# Altium schematic sheet Rikky-board_v0r0_SF2.SchDoc
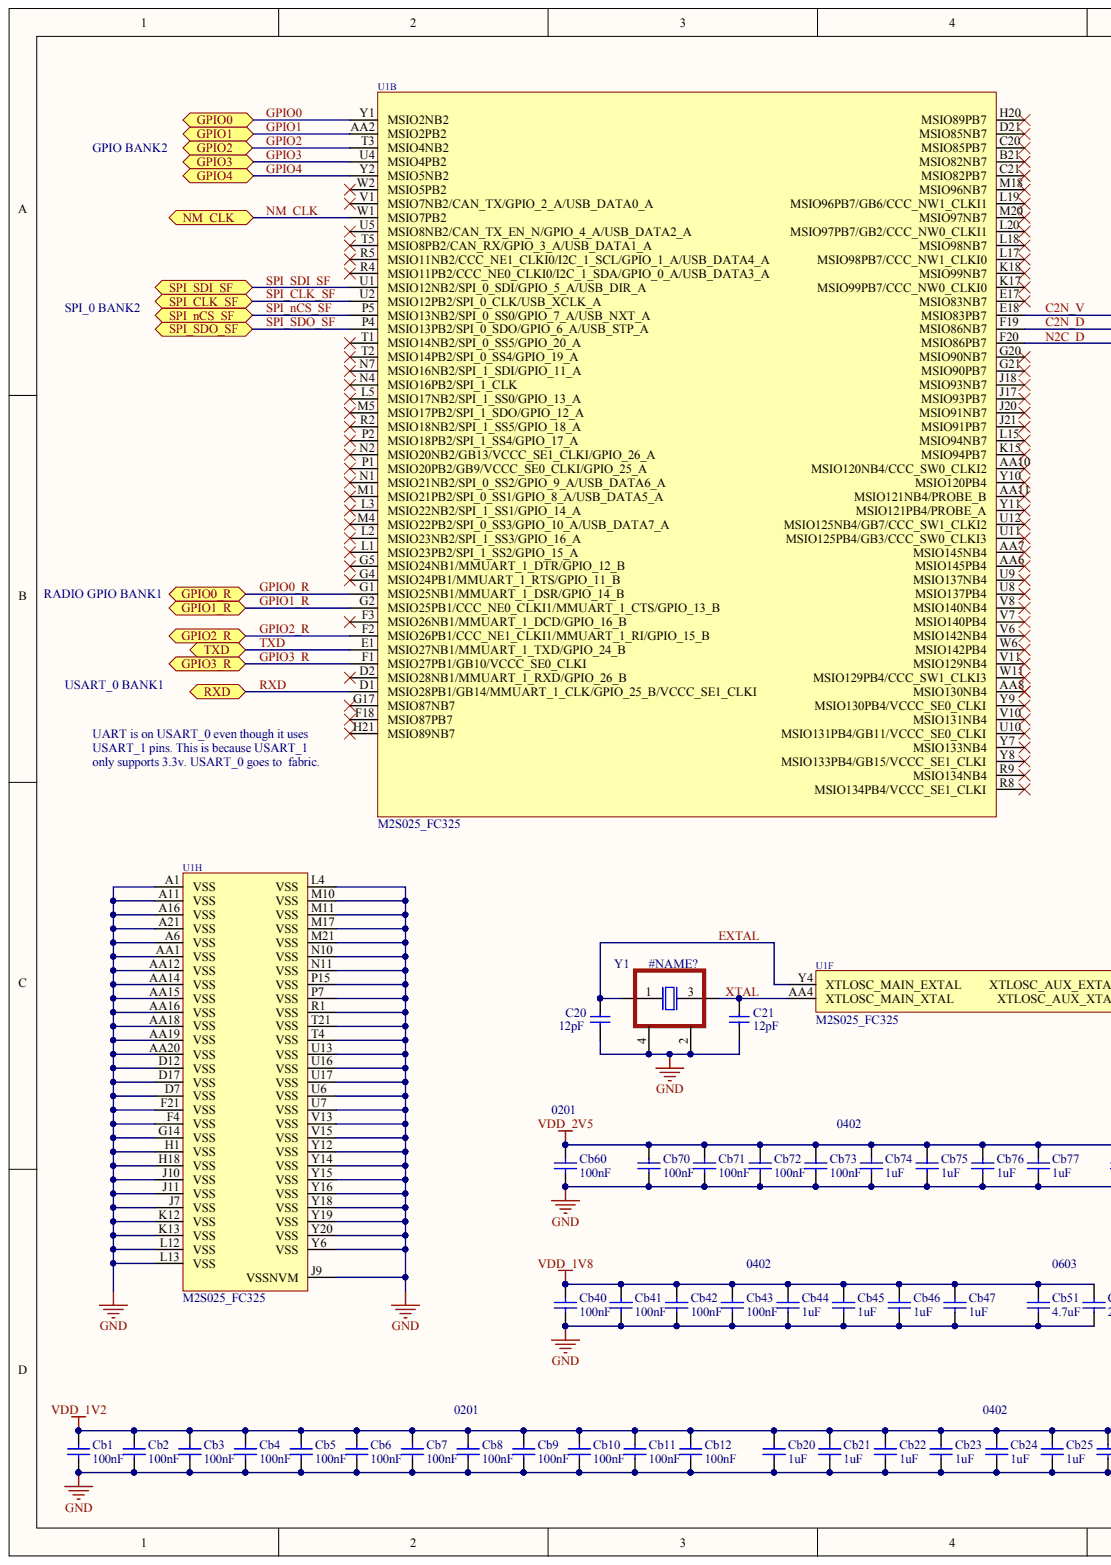
[diagram p0: Rikky-board_v0r0_SF2.SchDoc, left]
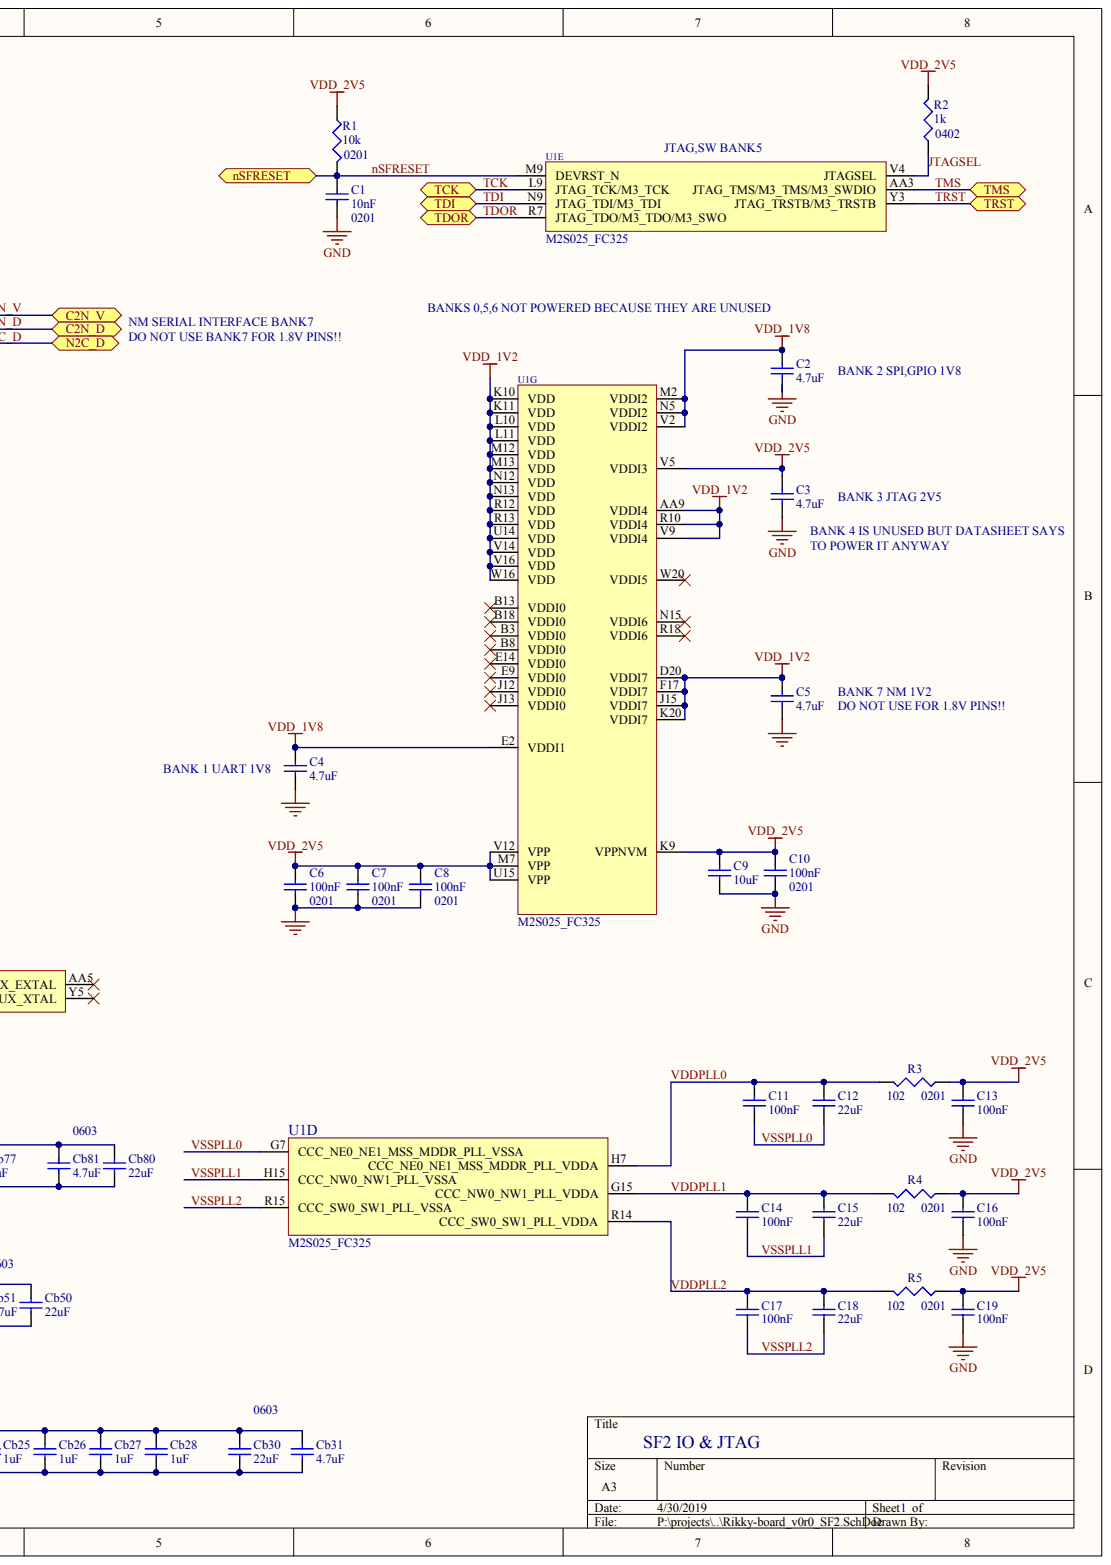
[diagram p1: Rikky-board_v0r0_SF2.SchDoc, right]

## Parts
C1  0201  value 10nF
C2  value 4.7uF  (hidden comment: GRM188C81E475KE11D)
C3  value 4.7uF  (hidden comment: GRM188C81E475KE11D)
C4  value 4.7uF  (hidden comment: GRM188C81E475KE11D)
C5  value 4.7uF  (hidden comment: GRM188C81E475KE11D)
C6  value 100nF  Package: 0201  (hidden comment: GRM033C71C104ME14D)
C7  value 100nF  Package: 0201  (hidden comment: GRM033C71C104ME14D)
C8  value 100nF  Package: 0201  (hidden comment: GRM033C71C104ME14D)
C9  value 10uF  (hidden comment: ZRB18AR61E106ME01L)
C10  value 100nF  Package: 0201  (hidden comment: GRM033C71C104ME14D)
C11  value 100nF  (hidden comment: GCM155R71C104KA55D)
C12  value 22uF  (hidden comment: GRM188R61A226ME15D)
C13  value 100nF  (hidden comment: GCM155R71C104KA55D)
C14  value 100nF  (hidden comment: GCM155R71C104KA55D)
C15  value 22uF  (hidden comment: GRM188R61A226ME15D)
C16  value 100nF  (hidden comment: GCM155R71C104KA55D)
C17  value 100nF  (hidden comment: GCM155R71C104KA55D)
C18  value 22uF  (hidden comment: GRM188R61A226ME15D)
C19  value 100nF  (hidden comment: GCM155R71C104KA55D)
C20  value 12pF  (hidden comment: GRM1555C1H120FA01D)
C21  value 12pF  (hidden comment: GRM1555C1H120FA01D)
Cb1  value 100nF  (hidden comment: GRM033C71C104ME14D)
Cb2  value 100nF  (hidden comment: GRM033C71C104ME14D)
Cb3  value 100nF  (hidden comment: GRM033C71C104ME14D)
Cb4  value 100nF  (hidden comment: GRM033C71C104ME14D)
Cb5  value 100nF  (hidden comment: GRM033C71C104ME14D)
Cb6  value 100nF  (hidden comment: GRM033C71C104ME14D)
Cb7  value 100nF  (hidden comment: GRM033C71C104ME14D)
Cb8  value 100nF  (hidden comment: GRM033C71C104ME14D)
Cb9  value 100nF  (hidden comment: GRM033C71C104ME14D)
Cb10  value 100nF  (hidden comment: GRM033C71C104ME14D)
Cb11  value 100nF  (hidden comment: GRM033C71C104ME14D)
Cb12  value 100nF  (hidden comment: GRM033C71C104ME14D)
Cb20  value 1uF  (hidden comment: GRM155R61E105KA12D)
Cb21  value 1uF  (hidden comment: GRM155R61E105KA12D)
Cb22  value 1uF  (hidden comment: GRM155R61E105KA12D)
Cb23  value 1uF  (hidden comment: GRM155R61E105KA12D)
Cb24  value 1uF  (hidden comment: GRM155R61E105KA12D)
Cb25  value 1uF  (hidden comment: GRM155R61E105KA12D)
Cb26  value 1uF  (hidden comment: GRM155R61E105KA12D)
Cb27  value 1uF  (hidden comment: GRM155R61E105KA12D)
Cb28  value 1uF  (hidden comment: GRM155R61E105KA12D)
Cb30  value 22uF  (hidden comment: GRM188R61A226ME15D)
Cb31  value 4.7uF  (hidden comment: GRM188C81E475KE11D)
Cb40  value 100nF  (hidden comment: GCM155R71C104KA55D)
Cb41  value 100nF  (hidden comment: GCM155R71C104KA55D)
Cb42  value 100nF  (hidden comment: GCM155R71C104KA55D)
Cb43  value 100nF  (hidden comment: GCM155R71C104KA55D)
Cb44  value 1uF  (hidden comment: GRM155R61E105KA12D)
Cb45  value 1uF  (hidden comment: GRM155R61E105KA12D)
Cb46  value 1uF  (hidden comment: GRM155R61E105KA12D)
Cb47  value 1uF  (hidden comment: GRM155R61E105KA12D)
Cb50  value 22uF  (hidden comment: GRM188R61A226ME15D)
Cb51  value 4.7uF  (hidden comment: GRM188C81E475KE11D)
Cb60  value 100nF  (hidden comment: GRM033C71C104ME14D)
Cb70  value 100nF  (hidden comment: GCM155R71C104KA55D)
Cb71  value 100nF  (hidden comment: GCM155R71C104KA55D)
Cb72  value 100nF  (hidden comment: GCM155R71C104KA55D)
Cb73  value 100nF  (hidden comment: GCM155R71C104KA55D)
Cb74  value 1uF  (hidden comment: GRM155R61E105KA12D)
Cb75  value 1uF  (hidden comment: GRM155R61E105KA12D)
Cb76  value 1uF  (hidden comment: GRM155R61E105KA12D)
Cb77  value 1uF  (hidden comment: GRM155R61E105KA12D)
Cb80  value 22uF  (hidden comment: GRM188R61A226ME15D)
Cb81  value 4.7uF  (hidden comment: GRM188C81E475KE11D)
R1  value 10k  Package: 0201  (hidden comment: ERJ-1GEF1002C)
R2  value 1k  Package: 0402
R3  value 102  Package: 0201  (hidden comment: ERJ-1GNF1020C)
R4  value 102  Package: 0201  (hidden comment: ERJ-1GNF1020C)
R5  value 102  Package: 0201  (hidden comment: ERJ-1GNF1020C)
U1  M2S025_FC325  (parts B,D,E,F,G,H)
Y1  NX2520SA-20.000000MHZ  (value not drawn)

## Ports
C2N_D, C2N_V, GPIO0, GPIO0_R, GPIO1, GPIO1_R, GPIO2, GPIO2_R, GPIO3, GPIO3_R, GPIO4, N2C_D, NM_CLK, NSFRESET, RXD, SPI_CLK_SF, SPI_NCS_SF, SPI_SDI_SF, SPI_SDO_SF, TCK, TDI, TDOR, TMS, TRST, TXD

## Nets
GND: C1.1 C2.1 C3.1 C4.1 C5.1 C6.1 C7.1 C8.1 C9.1 C10.1 C13.1 C16.1 C19.1 C20.1 C21.1 Cb1.1 Cb2.1 Cb3.1 Cb4.1 Cb5.1 Cb6.1 Cb7.1 Cb8.1 Cb9.1 Cb10.1 Cb11.1 Cb12.1 Cb20.1 Cb21.1 Cb22.1 Cb23.1 Cb24.1 Cb25.1 Cb26.1 Cb27.1 Cb28.1 Cb30.1 Cb31.1 Cb40.1 Cb41.1 Cb42.1 Cb43.1 Cb44.1 Cb45.1 Cb46.1 Cb47.1 Cb50.1 Cb51.1 Cb60.1 Cb70.1 Cb71.1 Cb72.1 Cb73.1 Cb74.1 Cb75.1 Cb76.1 Cb77.1 Cb80.1 Cb81.1 U1.A1(VSS) U1.A6(VSS) U1.A11(VSS) U1.A16(VSS) U1.A21(VSS) U1.AA1(VSS) U1.AA12(VSS) U1.AA14(VSS) U1.AA15(VSS) U1.AA16(VSS) U1.AA18(VSS) U1.AA19(VSS) U1.AA20(VSS) U1.D7(VSS) U1.D12(VSS) U1.D17(VSS) U1.F4(VSS) U1.F21(VSS) U1.G14(VSS) U1.H1(VSS) U1.H18(VSS) U1.J7(VSS) U1.J9(VSSNVM) U1.J10(VSS) U1.J11(VSS) U1.K12(VSS) U1.K13(VSS) U1.L4(VSS) U1.L12(VSS) U1.L13(VSS) U1.M10(VSS) U1.M11(VSS) U1.M17(VSS) U1.M21(VSS) U1.N10(VSS) U1.N11(VSS) U1.P7(VSS) U1.P15(VSS) U1.R1(VSS) U1.T4(VSS) U1.T21(VSS) U1.U6(VSS) U1.U7(VSS) U1.U13(VSS) U1.U16(VSS) U1.U17(VSS) U1.V13(VSS) U1.V15(VSS) U1.Y6(VSS) U1.Y12(VSS) U1.Y14(VSS) U1.Y15(VSS) U1.Y16(VSS) U1.Y18(VSS) U1.Y19(VSS) U1.Y20(VSS) Y1.2 Y1.4
VDD_1V2: C5.2 Cb1.2 Cb2.2 Cb3.2 Cb4.2 Cb5.2 Cb6.2 Cb7.2 Cb8.2 Cb9.2 Cb10.2 Cb11.2 Cb12.2 Cb20.2 Cb21.2 Cb22.2 Cb23.2 Cb24.2 Cb25.2 Cb26.2 Cb27.2 Cb28.2 Cb30.2 Cb31.2 U1.AA9(VDDI4) U1.D20(VDDI7) U1.F17(VDDI7) U1.J15(VDDI7) U1.K10(VDD) U1.K11(VDD) U1.K20(VDDI7) U1.L10(VDD) U1.L11(VDD) U1.M12(VDD) U1.M13(VDD) U1.N12(VDD) U1.N13(VDD) U1.R10(VDDI4) U1.R12(VDD) U1.R13(VDD) U1.U14(VDD) U1.V9(VDDI4) U1.V14(VDD) U1.V16(VDD) U1.W16(VDD)
VDD_1V8: C2.2 C4.2 Cb40.2 Cb41.2 Cb42.2 Cb43.2 Cb44.2 Cb45.2 Cb46.2 Cb47.2 Cb50.2 Cb51.2 U1.E2(VDDI1) U1.M2(VDDI2) U1.N5(VDDI2) U1.V2(VDDI2)
VDD_2V5: C3.2 C6.2 C7.2 C8.2 C9.2 C10.2 C13.2 C16.2 C19.2 Cb60.2 Cb70.2 Cb71.2 Cb72.2 Cb73.2 Cb74.2 Cb75.2 Cb76.2 Cb77.2 Cb80.2 Cb81.2 R1.1 R2.2 R3.1 R4.1 R5.1 U1.K9(VPPNVM) U1.M7(VPP) U1.U15(VPP) U1.V5(VDDI3) U1.V12(VPP)
C2N_D: U1.F19(MSIO86NB7) ; port C2N_D
C2N_V: U1.E18(MSIO83PB7) ; port C2N_V
EXTAL: C20.2 U1.Y4(XTLOSC_MAIN_EXTAL) Y1.1
GPIO0: U1.Y1(MSIO2NB2) ; port GPIO0
GPIO0_R: U1.G1(MSIO25NB1/MMUART_1_DSR/GPIO_14_B) ; port GPIO0_R
GPIO1: U1.AA2(MSIO2PB2) ; port GPIO1
GPIO1_R: U1.G2(MSIO25PB1/CCC_NE0_CLKI1/MMUART_1_CTS/GPIO_13_B) ; port GPIO1_R
GPIO2: U1.T3(MSIO4NB2) ; port GPIO2
GPIO2_R: U1.F2(MSIO26PB1/CCC_NE1_CLKI1/MMUART_1_RI/GPIO_15_B) ; port GPIO2_R
GPIO3: U1.U4(MSIO4PB2) ; port GPIO3
GPIO3_R: U1.F1(MSIO27PB1/GB10/VCCC_SE0_CLKI) ; port GPIO3_R
GPIO4: U1.Y2(MSIO5NB2) ; port GPIO4
JTAGSEL: R2.1 U1.V4(JTAGSEL)
N2C_D: U1.F20(MSIO86PB7) ; port N2C_D
NM_CLK: U1.W1(MSIO7PB2) ; port NM_CLK
NSFRESET: C1.2 R1.2 U1.M9(DEVRST_N) ; port NSFRESET
RXD: U1.D1(MSIO28PB1/GB14/MMUART_1_CLK/GPIO_25_B/VCCC_SE1_CLKI) ; port RXD
SPI_CLK_SF: U1.U2(MSIO12PB2/SPI_0_CLK/USB_XCLK_A) ; port SPI_CLK_SF
SPI_NCS_SF: U1.P5(MSIO13NB2/SPI_0_SS0/GPIO_7_A/USB_NXT_A) ; port SPI_NCS_SF
SPI_SDI_SF: U1.U1(MSIO12NB2/SPI_0_SDI/GPIO_5_A/USB_DIR_A) ; port SPI_SDI_SF
SPI_SDO_SF: U1.P4(MSIO13PB2/SPI_0_SDO/GPIO_6_A/USB_STP_A) ; port SPI_SDO_SF
TCK: U1.L9(JTAG_TCK/M3_TCK) ; port TCK
TDI: U1.N9(JTAG_TDI/M3_TDI) ; port TDI
TDOR: U1.R7(JTAG_TDO/M3_TDO/M3_SWO) ; port TDOR
TMS: U1.AA3(JTAG_TMS/M3_TMS/M3_SWDIO) ; port TMS
TRST: U1.Y3(JTAG_TRSTB/M3_TRSTB) ; port TRST
TXD: U1.E1(MSIO27NB1/MMUART_1_TXD/GPIO_24_B) ; port TXD
VDDPLL0: C11.2 C12.2 R3.2 U1.H7(CCC_NE0_NE1_MSS_MDDR_PLL_VDDA)
VDDPLL1: C14.2 C15.2 R4.2 U1.G15(CCC_NW0_NW1_PLL_VDDA)
VDDPLL2: C17.2 C18.2 R5.2 U1.R14(CCC_SW0_SW1_PLL_VDDA)
VSSPLL0: C11.1 C12.1 U1.G7(CCC_NE0_NE1_MSS_MDDR_PLL_VSSA)
VSSPLL1: C14.1 C15.1 U1.H15(CCC_NW0_NW1_PLL_VSSA)
VSSPLL2: C17.1 C18.1 U1.R15(CCC_SW0_SW1_PLL_VSSA)
XTAL: C21.2 U1.AA4(XTLOSC_MAIN_XTAL) Y1.3

Not connected: U1 AA5(XTLOSC_AUX_EXTAL) AA6(MSIO145PB4) AA7(MSIO145NB4) AA8(MSIO130NB4) AA10(MSIO120NB4/CCC_SW0_CLKI2) AA11(MSIO121NB4/PROBE_B) B3(VDDI0) B8(VDDI0) B13(VDDI0) B18(VDDI0) B21(MSIO82NB7) C20(MSIO85PB7) C21(MSIO82PB7) D2(MSIO28NB1/MMUART_1_RXD/GPIO_26_B) D21(MSIO85NB7) E9(VDDI0) E14(VDDI0) E17(MSIO83NB7) F3(MSIO26NB1/MMUART_1_DCD/GPIO_16_B) F18(MSIO87PB7) G4(MSIO24PB1/MMUART_1_RTS/GPIO_11_B) G5(MSIO24NB1/MMUART_1_DTR/GPIO_12_B) G17(MSIO87NB7) G20(MSIO90NB7) G21(MSIO90PB7) H20(MSIO89PB7) H21(MSIO89NB7) J12(VDDI0) J13(VDDI0) J17(MSIO93PB7) J18(MSIO93NB7) J20(MSIO91NB7) J21(MSIO91PB7) K15(MSIO94PB7) K17(MSIO99PB7/CCC_NW0_CLKI0) K18(MSIO99NB7) L1(MSIO23PB2/SPI_1_SS2/GPIO_15_A) L2(MSIO23NB2/SPI_1_SS3/GPIO_16_A) L3(MSIO22NB2/SPI_1_SS1/GPIO_14_A) L5(MSIO17NB2/SPI_1_SS0/GPIO_13_A) L15(MSIO94NB7) L17(MSIO98PB7/CCC_NW1_CLKI0) L18(MSIO98NB7) L19(MSIO96PB7/GB6/CCC_NW1_CLKI1) L20(MSIO97PB7/GB2/CCC_NW0_CLKI1) M1(MSIO21PB2/SPI_0_SS1/GPIO_8_A/USB_DATA5_A) M4(MSIO22PB2/SPI_0_SS3/GPIO_10_A/USB_DATA7_A) M5(MSIO17PB2/SPI_1_SDO/GPIO_12_A) M18(MSIO96NB7) M20(MSIO97NB7) N1(MSIO21NB2/SPI_0_SS2/GPIO_9_A/USB_DATA6_A) N2(MSIO20NB2/GB13/VCCC_SE1_CLKI/GPIO_26_A) N4(MSIO16PB2/SPI_1_CLK) N7(MSIO16NB2/SPI_1_SDI/GPIO_11_A) N15(VDDI6) P1(MSIO20PB2/GB9/VCCC_SE0_CLKI/GPIO_25_A) P2(MSIO18PB2/SPI_1_SS4/GPIO_17_A) R2(MSIO18NB2/SPI_1_SS5/GPIO_18_A) R4(MSIO11PB2/CCC_NE0_CLKI0/I2C_1_SDA/GPIO_0_A/USB_DATA3_A) R5(MSIO11NB2/CCC_NE1_CLKI0/I2C_1_SCL/GPIO_1_A/USB_DATA4_A) R8(MSIO134PB4/VCCC_SE1_CLKI) R9(MSIO134NB4) R18(VDDI6) T1(MSIO14NB2/SPI_0_SS5/GPIO_20_A) T2(MSIO14PB2/SPI_0_SS4/GPIO_19_A) T5(MSIO8PB2/CAN_RX/GPIO_3_A/USB_DATA1_A) U5(MSIO8NB2/CAN_TX_EN_N/GPIO_4_A/USB_DATA2_A) U8(MSIO137PB4) U9(MSIO137NB4) U10(MSIO131PB4/GB11/VCCC_SE0_CLKI) U11(MSIO125PB4/GB3/CCC_SW0_CLKI3) U12(MSIO125NB4/GB7/CCC_SW1_CLKI2) V1(MSIO7NB2/CAN_TX/GPIO_2_A/USB_DATA0_A) V6(MSIO142NB4) V7(MSIO140PB4) V8(MSIO140NB4) V10(MSIO131NB4) V11(MSIO129NB4) W2(MSIO5PB2) W6(MSIO142PB4) W11(MSIO129PB4/CCC_SW1_CLKI3) W20(VDDI5) Y5(XTLOSC_AUX_XTAL) Y7(MSIO133NB4) Y8(MSIO133PB4/GB15/VCCC_SE1_CLKI) Y9(MSIO130PB4/VCCC_SE0_CLKI) Y10(MSIO120PB4) Y11(MSIO121PB4/PROBE_A)

## Library data of the parts
C1 = (no symbol name): Manufacturer: Murata; Manufacturer PN: GRM033R61E103KA12D; Supplier: Digikey; Supplier PN: 490-7194-1-ND
C2-C5 C9 C11-C21 Cb20-Cb28 Cb30 Cb31 Cb40-Cb47 Cb50 Cb51 Cb70-Cb77 Cb80 Cb81 = (no symbol name): Manufacturer: Murata; Supplier: Digikey
  C2-C5 Cb31 Cb51 Cb81: Supplier PN: 490-7199-1-ND; Manufacturer PN: GRM188C81E475KE11D
  C9: Supplier PN: 490-10991-1-ND; Manufacturer PN: ZRB18AR61E106ME01L
  C11 C13 C14 C16 C17 C19 Cb40-Cb43 Cb70-Cb73: Manufacturer PN: GCM155R71C104KA55D; Supplier PN: 490-4759-1-ND
  C12 C15 C18 Cb30 Cb50 Cb80: Supplier PN: 490-10476-1-ND; Manufacturer PN: GRM188R61A226ME15D
  C20 C21: Manufacturer PN: GRM1555C1H120FA01D; Supplier PN: 490-6196-1-ND
  Cb20-Cb28 Cb44-Cb47 Cb74-Cb77: Manufacturer PN: GRM155R61E105KA12D; Supplier PN: 490-10017-1-ND
C6-C8 C10 Cb1-Cb12 Cb60 = (no symbol name): Manufacturer: Murata; Note: 16V 20% X7S; Supplier PN: 490-10388-1-ND; Supplier: Digikey; Manufacturer PN: GRM033C71C104ME14D
R1 R3-R5 = (no symbol name): Manufacturer: Panasonic; Power: 1/20W; Supplier: Digikey
  R1: Manufacturer PN: ERJ-1GEF1002C; Supplier P/N: P10.0KABCT-ND
  R3-R5: Manufacturer PN: ERJ-1GNF1020C; Supplier P/N: P122657CT-ND
R2 = (no symbol name): Manufacturer: Panasonic; Supplier: Digikey
U1 = (no symbol name): Manufacturer PN: M2S025-FCS325; Manufacturer: Microsemi; Supplier: Microsemi; Supplier PN: M2S025-FCS325
Y1 = (no symbol name): Manufacturer: NDK; Supplier PN: 644-1060-6-ND; Supplier: Digikey; Manufacturer PN: NX2520SA-20.000000MHZ
